# Altium schematic sheet External_Board_3mode.SchDoc
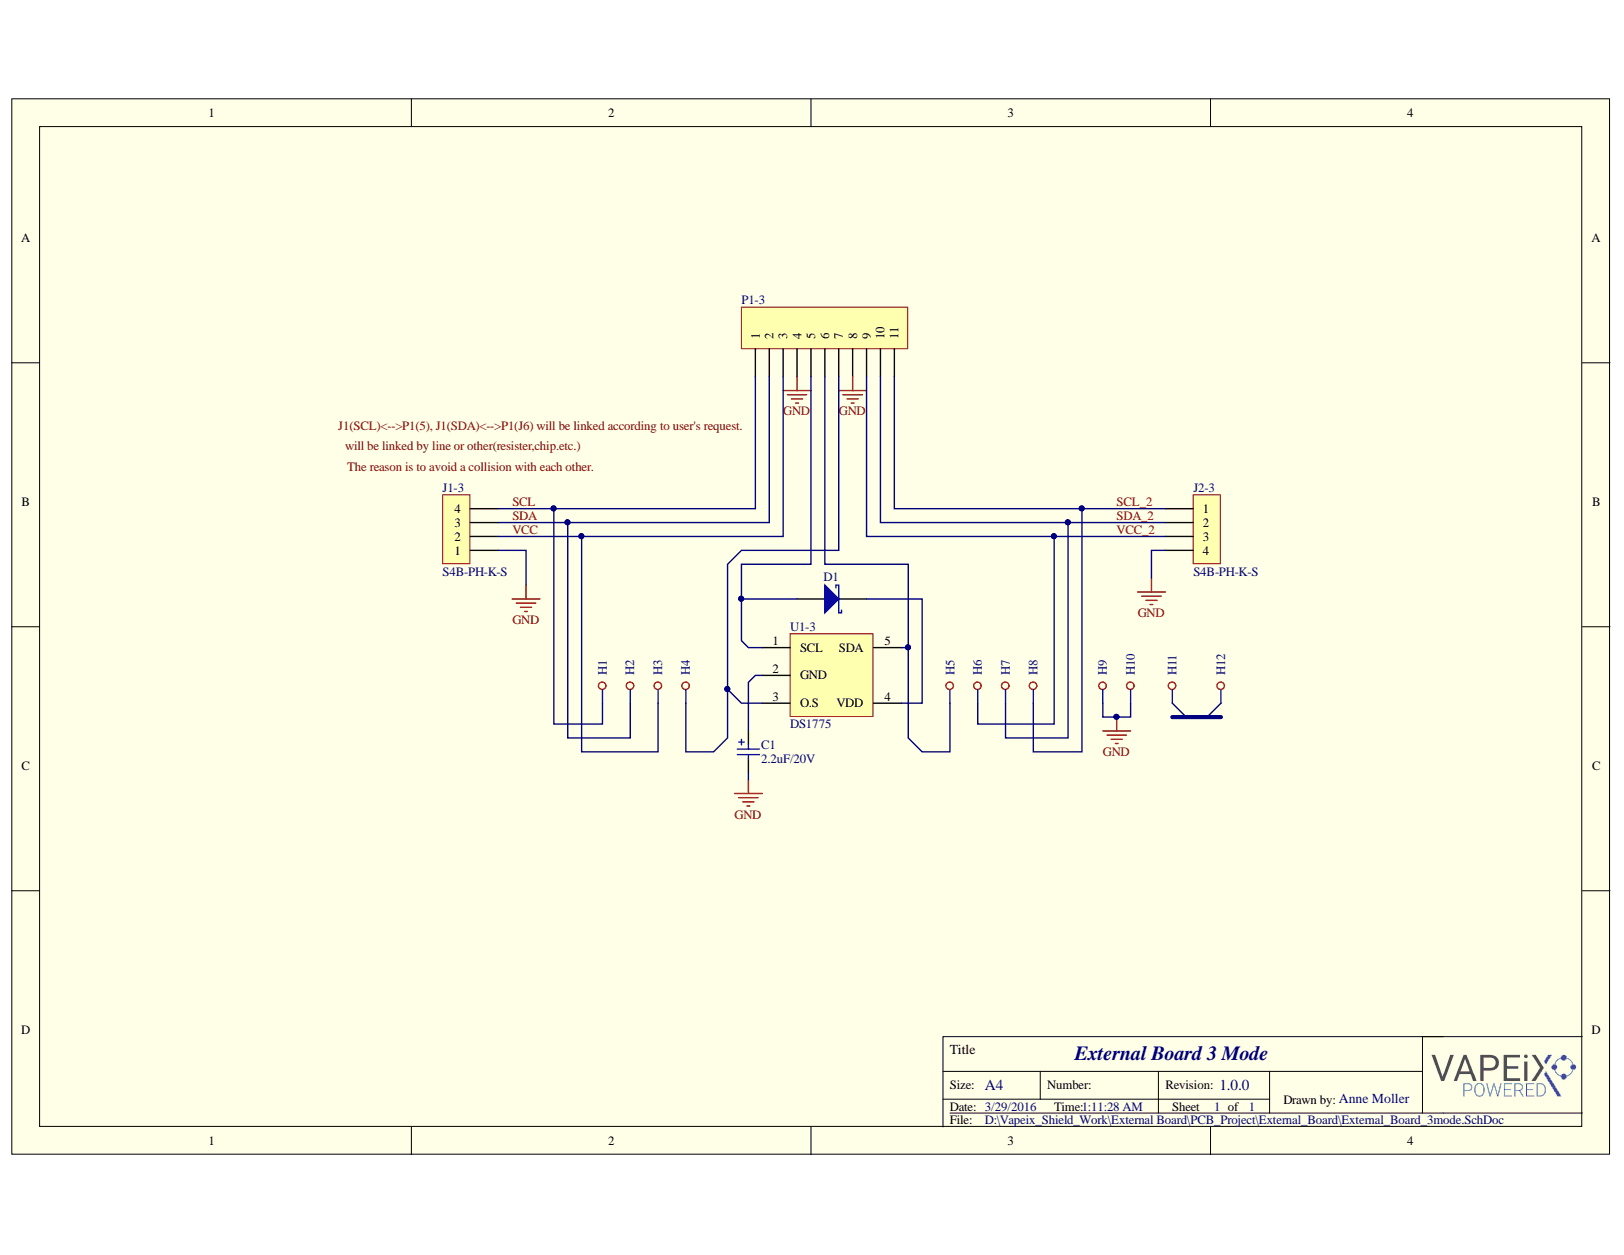
[diagram p0: External_Board_3mode.SchDoc]

## Parts
C1  2.2uF/20V  [C1206]
D1  MSS2P2-M3/89A  [MSS2P2-M3]  (value not drawn)
H1  TP_HOLE  [TestHole]  (value not drawn)
H2  TP_HOLE  [TestHole]  (value not drawn)
H3  TP_HOLE  [TestHole]  (value not drawn)
H4  TP_HOLE  [TestHole]  (value not drawn)
H5  TP_HOLE  [TestHole]  (value not drawn)
H6  TP_HOLE  [TestHole]  (value not drawn)
H7  TP_HOLE  [TestHole]  (value not drawn)
H8  TP_HOLE  [TestHole]  (value not drawn)
H9  TP_HOLE  [TestHole]  (value not drawn)
H10  TP_HOLE  [TestHole]  (value not drawn)
H11  TP_HOLE  [TestHole]  (value not drawn)
H12  TP_HOLE  [TestHole]  (value not drawn)
J1-3  S4B-PH-K-S  [CON4]
J2-3  S4B-PH-K-S  [CON4]
P1-3  Header11  [CON11]  (value not drawn)
U1-3  DS1775  [DS1775]

## Nets
GND: C1.2 H9.1 H10.1 J1-3.1 J2-3.4 P1-3.4 P1-3.8
SCL: H1.1 J1-3.4 P1-3.1
SCL_2: H8.1 J2-3.1 P1-3.11
SDA: H2.1 J1-3.3 P1-3.2
SDA_2: H7.1 J2-3.2 P1-3.10
VCC: H3.1 J1-3.2 P1-3.3
VCC_2: H6.1 J2-3.3 P1-3.9
NetC1_1: C1.1 U1-3.2(GND)
NetD1_1: D1.1 P1-3.5 U1-3.1(SCL)
NetD1_2: D1.2 U1-3.4(VDD)
NetH4_1: H4.1 P1-3.7 U1-3.3(O.S)
NetH5_1: H5.1 P1-3.6 U1-3.5(SDA)
J1(SCL)<-->P1(5), J1(SDA)<-->P1(J6) WILL BE LINKED ACCORDING TO USER'S REQUEST.:
N00048:
THE REASON IS TO AVOID A COLLISION WITH EACH OTHER.:
WILL BE LINKED BY LINE OR OTHER(RESISTER,CHIP.ETC.):

Not connected: H11 1; H12 1

## Library data of the parts
C1 = TAJA225K020RNJ: CAP TANT 2.2UF 20V 10% 1206; Manufacturer: AVX Corporation; Manufacturer Size Code: A; Capacitance: 2.2µF; ESR (Equivalent Series Resistance): 5.3 Ohm; Type: Molded; Category: Capacitors; Component Kind: Standard; ComponentLink1Description: http://datasheets.avx.com/TAJ.pdf; ComponentLink1URL: http://datasheets.avx.com/TAJ.pdf; Features: General Purpose; Height - Seated (Max): 0.071" (1.80mm); Lead Spacing: -; Lifetime @ Temp.: -; Manufacturer Part Number: TAJA225K020RNJ; Mounting Type: Surface Mount; Operating Temperature: -55°C ~ 125°C; Package / Case: 1206 (3216 Metric); Packaging: Digi-Reel®; Pricing 1: 1=0.35, 10=0.295, 100=0.1476, 250=0.11072, 500=0.09778, 1000=0.08672 (USD); RoHS: RoHS Compliant; Size / Dimension: 0.126" L x 0.063" W (3.20mm x 1.60mm); Stock 1: 864; Supplier 1: Digi-Key; Tolerance: ±10%; Voltage - Rated: 20V; Library Name: ExternalLib.SchLib
D1 = MSS2P2-M3/89A: DIODE SCHOTTKY 20V 2A MICROSMP; Manufacturer: Vishay Semiconductor Diodes Division; Capacitance @ Vr, F: 65pF @ 4V, 1MHz; Current - Reverse Leakage @ Vr: 250µA @ 20V; Speed: Fast Recovery =< 500ns, > 200mA (Io); Voltage - Forward (Vf) (Max) @ If: 600mV @ 2A; Category: Discrete Semiconductor Products; Component Kind: Standard; ComponentLink1Description: http://www.vishay.com/docs/89054/mss2p3.pdf; ComponentLink1URL: http://www.vishay.com/docs/89054/mss2p3.pdf; Current - Average Rectified (Io): 2A; Diode Type: Schottky; Manufacturer Part Number: MSS2P2-M3/89A; Mounting Type: Surface Mount; Operating Temperature - Junction: -55°C ~ 150°C; Package / Case: MicroSMP; Packaging: Cut Tape (CT); Pricing 1: 1=0.37, 10=0.274, 100=0.1552, 500=0.1029, 1000=0.07892 (USD); Reverse Recovery Time (trr): -; RoHS: RoHS Compliant; Stock 1: 16161; Supplier 1: Digi-Key; Supplier Device Package: MicroSMP; Voltage - DC Reverse (Vr) (Max): 20V; Library Name: ExternalLib.SchLib
H1-H12 = TP_HOLE: TEST POINT HOLE; Component Kind: Standard; Library Name: ExternalLib.SchLib
J1-3 J2-3 = S4B-PH-K-S (LF)(SN): JST (JAPAN SOLDERLESS TERMINALS) - S4B-PH-K-S (LF)(SN) - CONNECTOR, HEADER, 2MM, 4 POSITION, 1ROW; Component Kind: Standard; ComponentLink1Description: http://www.farnell.com/datasheets/80564.pdf; ComponentLink1URL: http://www.farnell.com/datasheets/80564.pdf; Manufacturer: JST (JAPAN SOLDERLESS TERMINALS); Manufacturer Part Number: S4B-PH-K-S (LF)(SN); Pricing 1: 1=0.14, 250=0.126, 500=0.112, 1000=0.101, 5000=0.069 (USD); RoHS: YES; Stock 1: 908; Supplier 1: Newark; Library Name: ExternalLib.SchLib
P1-3 = SFH11-PBPC-D10-ST-BK: CONN HEADR FMALE 20POS .1" DL AU; Manufacturer: Sullins Connector Solutions; Connector Type: Header; Number of Positions: 20; Number of Positions Loaded: All; Pitch: 0.100" (2.54mm); Category: Connectors, Interconnects; Color: Black; Component Kind: Standard; ComponentLink1Description: http://sullinscorp.com/catalogs/146_PAGE119_.100_SFH11_SERIES_FEMALE_HDR_ST_RA.pdf; ComponentLink1URL: http://sullinscorp.com/catalogs/146_PAGE119_.100_SFH11_SERIES_FEMALE_HDR_ST_RA.pdf; Contact Finish: Gold; Contact Finish Thickness: Flash; Contact Type: Female Socket; Fastening Type: -; Features: Polarizing Key; Manufacturer Part Number: SFH11-PBPC-D10-ST-BK; Mounting Type: Through Hole; Number of Rows: 2; Packaging: Tray; Pricing 1: 1=1.43, 10=1.185, 25=1.0976, 50=0.9878, 100=0.878, 250=0.76824, 500=0.7024, 1000=0.63655, 2500=0.5707 (USD); RoHS: RoHS Compliant; Row Spacing: 0.100" (2.54mm); Stock 1: 14457; Supplier 1: Digi-Key; Termination: Solder; Library Name: ExternalLib.SchLib
U1-3 = DS1775R4+T&R: SENSOR TEMPERATURE I2C SOT23-5; Manufacturer: Maxim Integrated; Accuracy - Highest (Lowest): ±2°C (±3°C); Output Type: I²C; Resolution: 11 b; Sensing Temperature - Local: -55°C ~ 125°C; Sensor Type: Digital, Local; Test Condition: 10°C ~ 85°C (-55°C ~ 125°C); Voltage - Supply: 2.7 V ~ 5.5 V; Category: Sensors, Transducers; Component Kind: Standard; ComponentLink1Description: http://datasheets.maximintegrated.com/en/ds/DS1775-DS1775R.pdf; ComponentLink1URL: http://datasheets.maximintegrated.com/en/ds/DS1775-DS1775R.pdf; Features: Output Switch, Programmable Limit, Programmable Resolution, Shutdown Mode; Manufacturer Part Number: DS1775R4+T&R; Operating Temperature: -55°C ~ 125°C; Package / Case: SC-74A, SOT-753; Packaging: Cut Tape (CT); Pricing 1: 1=2.14, 10=2.015, 25=1.6116, 50=1.531, 100=1.4102, 250=1.33764, 500=1.28928 (USD); RoHS: RoHS Compliant; Sensing Temperature - Remote: -; Stock 1: 5995; Supplier 1: Digi-Key; Supplier Device Package: SOT-23-5; Library Name: ExternalLib.SchLib
